# Altium schematic sheet int_pwrin.SchDoc
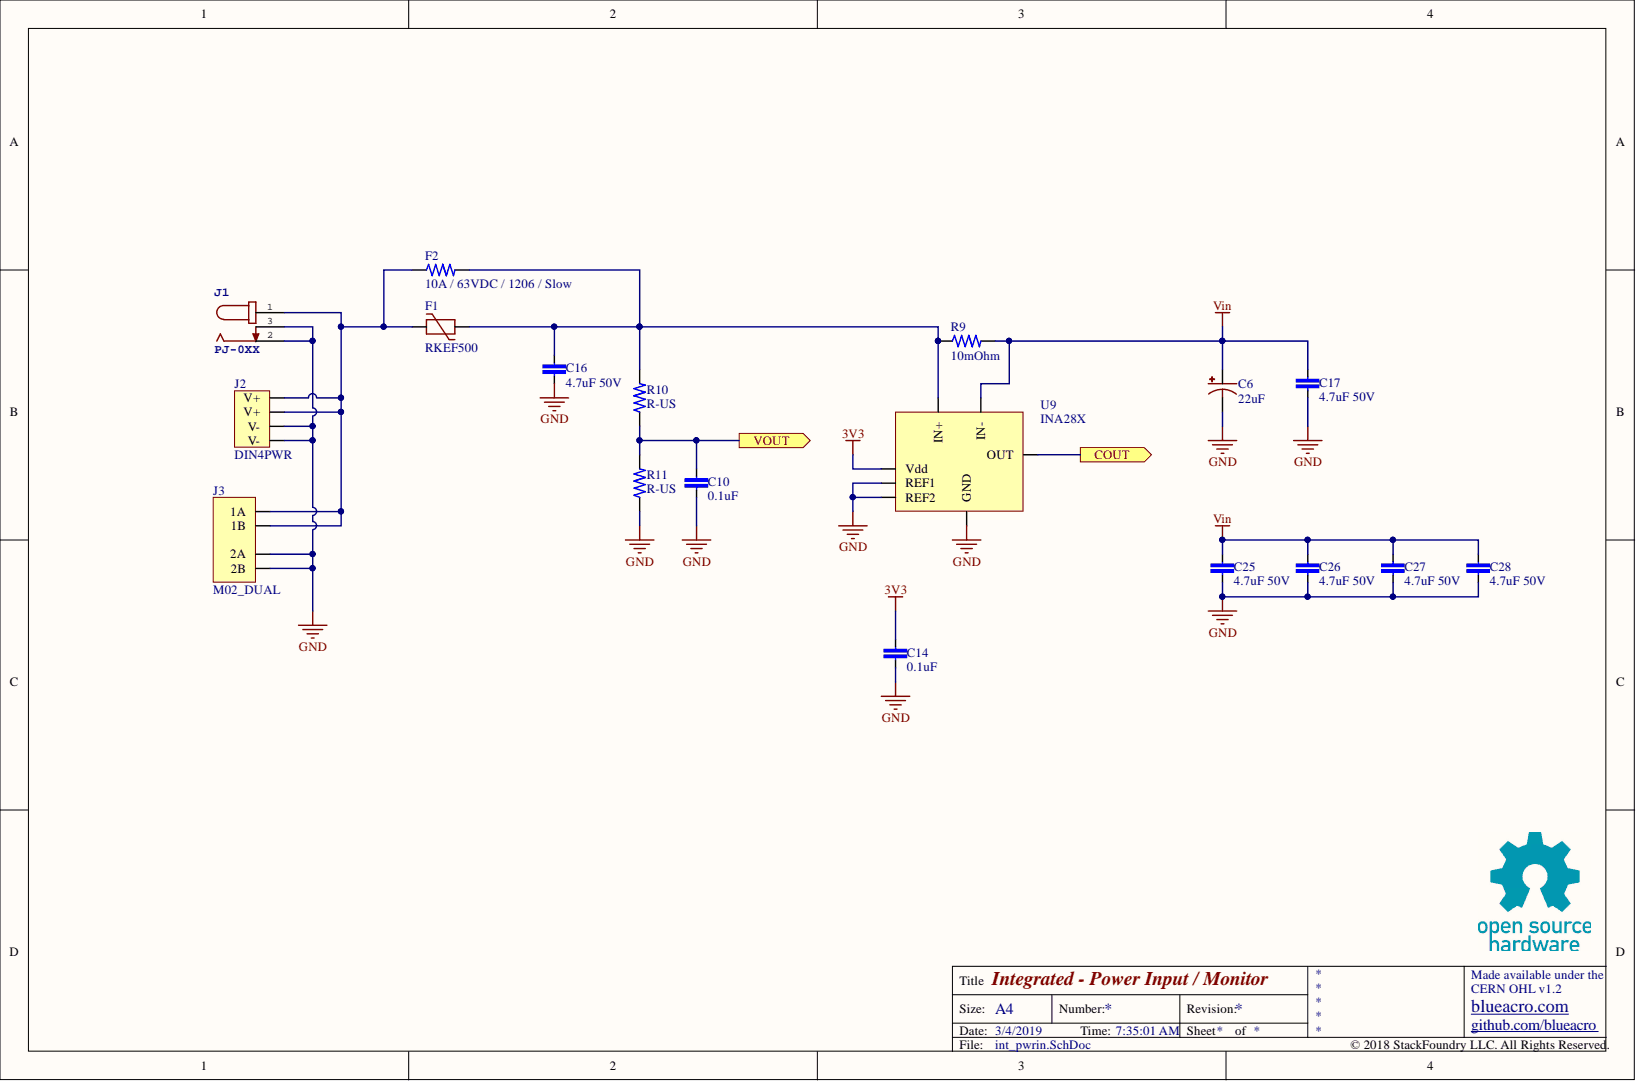
[diagram p0: int_pwrin.SchDoc]

## Parts
C6  22uF  [PANASONIC_G]
C10  0.1uF  [C0603]
C14  0.1uF  [C0603]
C16  4.7uF 50V  [C1210]
C17  4.7uF 50V  [C1210]
C25  4.7uF 50V  [C1210]
C26  4.7uF 50V  [C1210]
C27  4.7uF 50V  [C1210]
C28  4.7uF 50V  [C1210]
F1  RKEF500  [RKEF500]
F2  10A / 63VDC / 1206 / Slow  [R1206]
J1  PJ-0XX  [PJ-036AH]
J2  DIN4PWR  [PD40S]
J3  M02_DUAL  [PHOENIX_1990973]
R9  10mOhm  [R2512]
R10  R-US  [R0603]
R11  R-US  [R0603]
U9  INA28X  [SO-08]

## Ports
COUT, VOUT

## Nets
3V3: C14.1 U9.6(Vdd)
GND: C6.- C10.2 C14.2 C16.2 C17.2 C25.2 C26.2 C27.2 C28.2 J1.2 J1.3 J2.1(V-) J2.3(V-) J3.2A J3.2B R11.1 U9.2(GND) U9.3(REF2) U9.7(REF1)
Vin: C6.+ C17.1 C25.1 C26.1 C27.1 C28.1 R9.2 U9.1(IN-)
COUT: U9.5(OUT) ; port COUT
VOUT: C10.1 R10.1 R11.2 ; port VOUT
NetC16_1: C16.1 F1.2 F2.2 R9.1 R10.2 U9.8(IN+)
NetF1_1: F1.1 F2.1 J1.1 J2.2(V+) J2.4(V+) J3.1A J3.1B

## Library data of the parts
C6 = CPOL-US: Component Kind: Standard; Library Name: rcl.IntLib
C10 C14 C16 C17 C25-C28 = C-EU: Component Kind: Standard; Library Name: rcl.IntLib
F1 = PTC: Component Kind: Standard; Library Name: rcl.IntLib
F2 R9 = R-US: Component Kind: Standard; Library Name: rcl.IntLib
J1 = PJ-0XX: Component Kind: Standard; Library Name: connectors.IntLib
J2 = DIN4PWR: Component Kind: Standard; Library Name: connectors.IntLib
J3 = M02_DUAL: Component Kind: Standard; Library Name: connectors.IntLib
R10 R11 = R-US: Component Kind: Standard; Library Name: rcl.IntLib
U9 = INA28X: Component Kind: Standard; Library Name: texas.IntLib
